# Altium schematic sheet Schematic_Board2.SchDoc
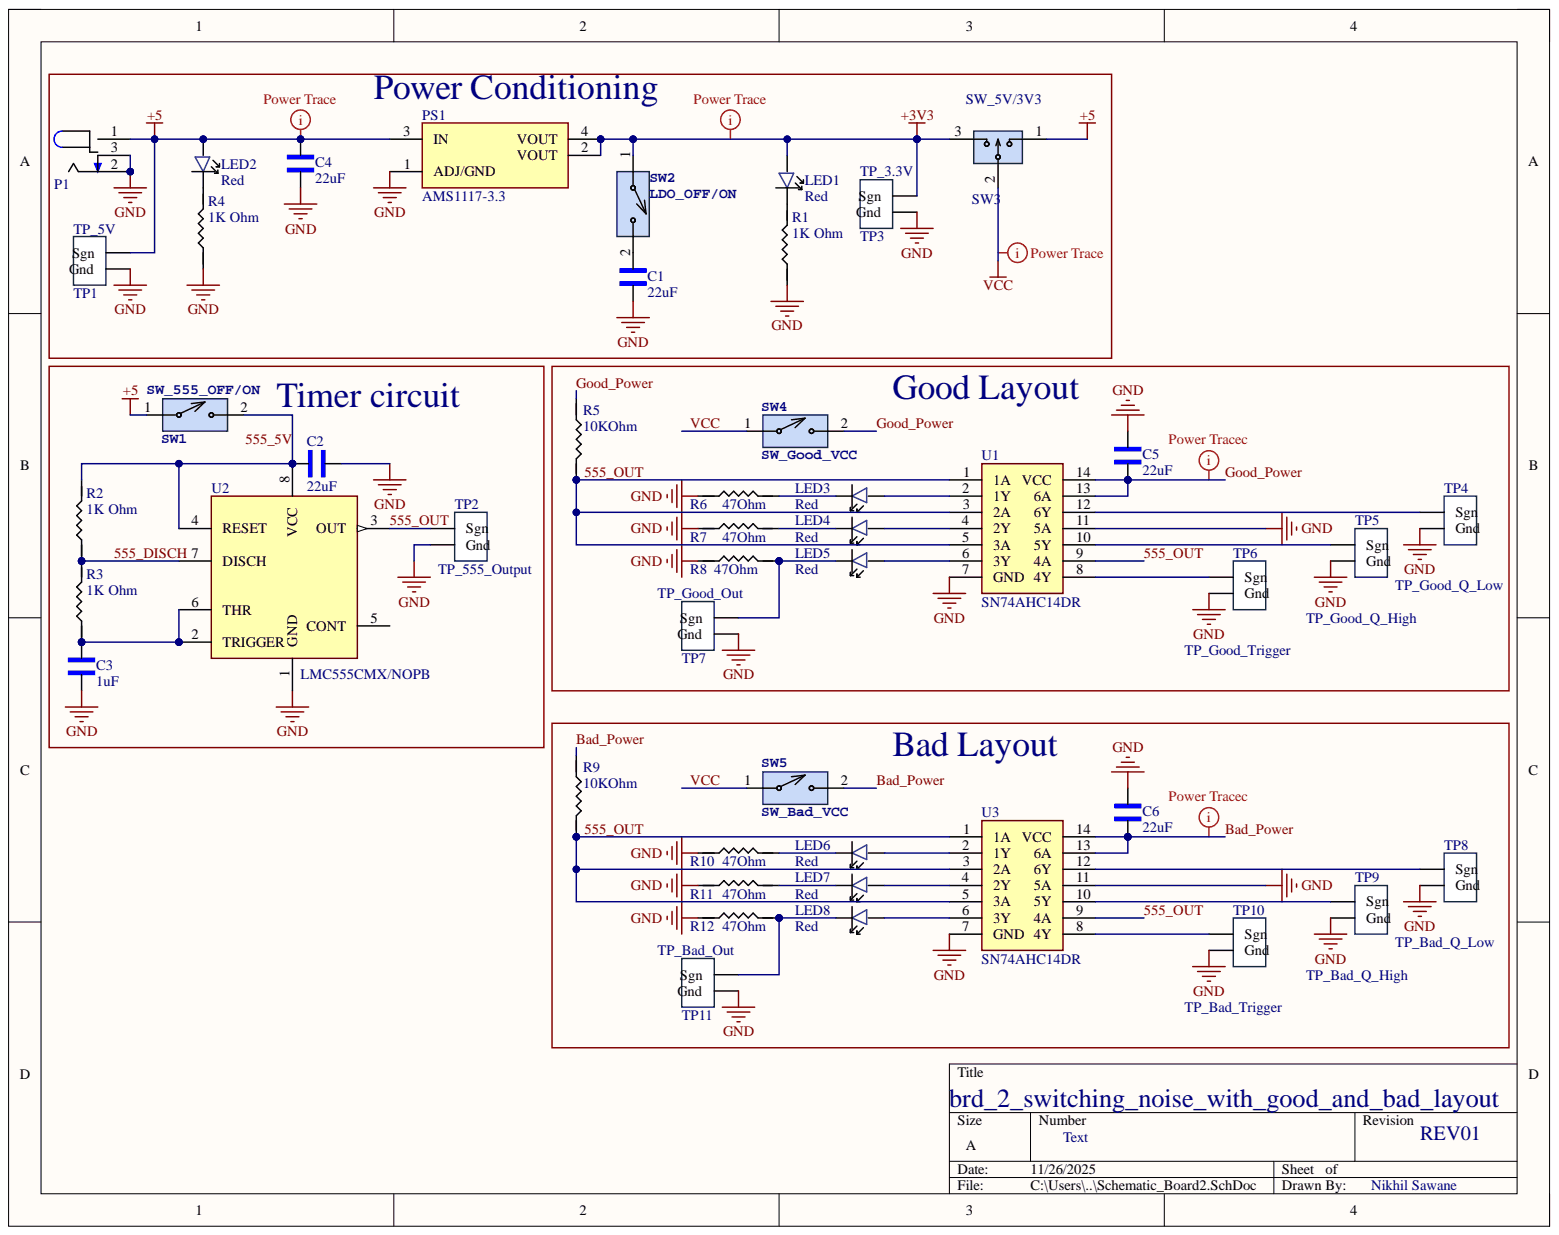
[diagram p0: Schematic_Board2.SchDoc]

## Parts
C1  22uF  [1206_Passive_Capacitor]
C2  22uF  [1206_Passive_Capacitor]
C3  1uF  [1206_Passive_Capacitor]
C4  22uF  [1206_Passive_Capacitor]
C5  22uF  [1206_Passive_Capacitor]
C6  22uF  [1206_Passive_Capacitor]
LED1  Red  [LED_1206]
LED2  Red  [LED_1206]
LED3  Red  [LED_1206]
LED4  Red  [LED_1206]
LED5  Red  [LED_1206]
LED6  Red  [LED_1206]
LED7  Red  [LED_1206]
LED8  Red  [LED_1206]
P1  Power Jack  [Power_Jack]  (value not drawn)
PS1  AMS1117-3.3  [LDO]
R1  value 1K Ohm  [1206_Passive_Resitor]  (hidden comment: 1k)
R2  value 1K Ohm  [1206_Passive_Resitor]  (hidden comment: 1k)
R3  value 1K Ohm  [1206_Passive_Resitor]  (hidden comment: 1k)
R4  value 1K Ohm  [1206_Passive_Resitor]  (hidden comment: 1k)
R5  value 10KOhm  [1206_Passive_Resitor]  (hidden comment: 10k)
R6  value 47Ohm  [1206_Passive_Resitor]  (hidden comment: 47 Ohms)
R7  value 47Ohm  [1206_Passive_Resitor]  (hidden comment: 47 Ohms)
R8  value 47Ohm  [1206_Passive_Resitor]  (hidden comment: 47 Ohms)
R9  value 10KOhm  [1206_Passive_Resitor]  (hidden comment: 10k)
R10  value 47Ohm  [1206_Passive_Resitor]  (hidden comment: 47 Ohms)
R11  value 47Ohm  [1206_Passive_Resitor]  (hidden comment: 47 Ohms)
R12  value 47Ohm  [1206_Passive_Resitor]  (hidden comment: 47 Ohms)
SW1  SW_555_OFF/ON  [2Pin-Header-Conn]
SW2  LDO_OFF/ON  [2Pin-Header-Conn]
SW3  SW_5V/3V3  [3_PIN_100mil]
SW4  SW_Good_VCC  [2Pin-Header-Conn]
SW5  SW_Bad_VCC  [2Pin-Header-Conn]
TP1  TP_5V  [TP10x_Probe]
TP2  TP_555_Output  [TP10x_Probe]
TP3  TP_3.3V  [TP10x_Probe]
TP4  TP_Good_Q_Low  [TP10x_Probe]
TP5  TP_Good_Q_High  [TP10x_Probe]
TP6  TP_Good_Trigger  [TP10x_Probe]
TP7  TP_Good_Out  [TP10x_Probe]
TP8  TP_Bad_Q_Low  [TP10x_Probe]
TP9  TP_Bad_Q_High  [TP10x_Probe]
TP10  TP_Bad_Trigger  [TP10x_Probe]
TP11  TP_Bad_Out  [TP10x_Probe]
U1  SN74AHC14DR  [HEX_74AC14]
U2  LMC555CMX/NOPB  [TS555IDTTR]
U3  SN74AHC14DR  [HEX_74AC14]

## Nets
+3V3: LED1.1 PS1.2(VOUT) PS1.4(VOUT) SW2.1 SW3.3 TP3.1
+5: C4.2 LED2.1 P1.1 PS1.3(IN) SW1.1 SW3.1 TP1.1
GND: C1.1 C2.1 C3.1 C4.1 C5.2 C6.2 P1.2 P1.3 PS1.1(ADJ/GND) R1.1 R4.1 R6.1 R7.1 R8.1 R10.1 R11.1 R12.1 TP1.2 TP2.2 TP3.2 TP4.2 TP5.2 TP6.2 TP7.2 TP8.2 TP9.2 TP10.2 TP11.2 U1.7(GND) U1.11(5A) U2.1(GND) U3.7(GND) U3.11(5A)
555_5V: C2.2 R2.2 SW1.2 U2.4(RESET) U2.8(VCC)
555_DISCH: R2.1 R3.2 U2.7(DISCH)
555_OUT: R5.2 R9.2 TP2.1 U1.1(1A) U1.3(2A) U1.5(3A) U1.9(4A) U2.3(OUT) U3.1(1A) U3.3(2A) U3.5(3A) U3.9(4A)
Bad_Power: C6.1 R9.1 SW5.2 U3.13(6A) U3.14(VCC)
Good_Power: C5.1 R5.1 SW4.2 U1.13(6A) U1.14(VCC)
VCC: SW3.2 SW4.1 SW5.1
NetC1_2: C1.2 SW2.2
NetC3_2: C3.2 R3.1 U2.2(TRIGGER) U2.6(THR)
NetLED1_2: LED1.2 R1.2
NetLED2_2: LED2.2 R4.2
NetLED3_1: LED3.1 U1.2(1Y)
NetLED3_2: LED3.2 R6.2
NetLED4_1: LED4.1 U1.4(2Y)
NetLED4_2: LED4.2 R7.2
NetLED5_1: LED5.1 U1.6(3Y)
NetLED5_2: LED5.2 R8.2 TP7.1
NetLED6_1: LED6.1 U3.2(1Y)
NetLED6_2: LED6.2 R10.2
NetLED7_1: LED7.1 U3.4(2Y)
NetLED7_2: LED7.2 R11.2
NetLED8_1: LED8.1 U3.6(3Y)
NetLED8_2: LED8.2 R12.2 TP11.1
NetTP4_1: TP4.1 U1.12(6Y)
NetTP5_1: TP5.1 U1.10(5Y)
NetTP6_1: TP6.1 U1.8(4Y)
NetTP8_1: TP8.1 U3.12(6Y)
NetTP9_1: TP9.1 U3.10(5Y)
NetTP10_1: TP10.1 U3.8(4Y)

Not connected: U2 5(CONT)

## Library data of the parts
C1 C2 C4-C6 = C_22uF_1206: 22uF ±10% 25V X5R 1206 Multilayer Ceramic Capacitors MLCC - SMD/SMT RoHS; MFR Part #: CL31A226KAHNNNE; Type: basic; Component Kind: Standard; Datasheet: https://datasheet.lcsc.com/lcsc/1811031514_Samsung-Electro-Mechanics-CL31A226KAHNNNE_C12891.pdf; LCSC Part#: C12891; Library Name: ECEN5730Lib_2023_05_DS.IntLib; Value: 22uF
C3 = C_1uF_1206: MULTILAYER CERAMIC CAPACITORS MLCC - SMD/SMT 1UF 50V 1206 ROHS; MFR Part #: CL31B105KBHNNNE; Type: Basic; Component Kind: Standard; Digikey Link: https://www.digikey.com/product-detail/en/C1206C105M4RACTU/399-8150-1-ND/3471873/?itemSeq=304982366; LCSC Part#: C1848; Library Name: ECEN5730Lib_2023_05_DS.IntLib; Value: 1uF
LED1-LED8 = LED_RED_1206: Red 621~631nm 1206 Light Emitting Diodes (LED) RoHS; MFR Part #: 15-21/R6C-FQ1R1B/2T; Type: Extended; Component Kind: Standard; Datasheet: https://datasheet.lcsc.com/szlcsc/1811151241_Everlight-Elec-15-21-R6C-FQ1R1B-2T_C93133.pdf; LCSC Part#: C93133; Library Name: ECEN5730Lib_2023_05_DS.IntLib; Value: Red
P1 = P_Power Jack: Power Barrel Connector Jack 2.10mm ID (0.083"), 5.50mm OD (0.217") Through Hole, Right Angle; MFR Part #: PJ-102A; Value: CONN PWR JACK 2X5.5MM SOLDER; Component Kind: Standard; Digikey Link: https://www.digikey.com/product-detail/en/PJ-102A/CP-102A-ND/275425/?itemSeq=304982367; LCSC Part#: C136744; Library Name: ECEN5730Lib_2023_05_DS.IntLib; Type: Extended
PS1 = PS_AMS_LDO_3v3: LOW DROPOUT REGULATORS(LDO) POSITIVE FIXED 1.3V @ 800MA 15V 3.3V 1A SOT-223 ROHS; Value: IC REG LINEAR 3.3V 800MA SOT223; Component Kind: Standard; LCSC Part#: C6186; Library Name: ECEN5730Lib_2023_05_DS.IntLib; MFR Part #: AMS1117-3.3; Type: Basic
R1-R4 = R_1K_1206: CHIP RESISTOR - SURFACE MOUNT 1KOHMS ±1% 1/4W 1206 ROHS; MFR Part #: RNCP1206FTD1K00CT; Component Kind: Standard; Digikey Link: https://www.digikey.com/product-detail/en/RNCP1206FTD1K00/RNCP1206FTD1K00CT-ND/2240675/?itemSeq=304982373; LCSC Part#: C4410; Library Name: ECEN5730Lib_2023_05_DS.IntLib; Type: Basic
R5 R9 = R_10KOhm_1206: 10 kOhms ±5% 0.25W, 1/4W Chip Resistor 1206 (3216 Metric) Automotive AEC-Q200 Thick Film; MFR Part #: RMCF1206FT10K0; Component Kind: Standard; Digikey Link: https://www.digikey.com/en/products/detail/stackpole-electronics-inc/RMCF1206FT10K0/1759669; LCSC Part#: C17902; Library Name: ECEN5730Lib_2023_05_DS.IntLib; Type: Basic
R6-R8 R10-R12 = R_47Ohm_1206: 47Ω ±5% 0.25W ±200ppm/℃ 1206 Chip Resistor - Surface Mount RoHS; MFR Part #: 1206W4J0470T5E; Component Kind: Standard; Datasheet: https://datasheet.lcsc.com/lcsc/1811101020_UNI-ROYAL-Uniroyal-Elec-1206W4J0470T5E_C25384.pdf; LCSC Part#: C25384; Library Name: ECEN5730Lib_2023_05_DS.IntLib; Type: Extended
SW1 SW2 SW4 SW5 = SW_2Pin_100mil_Switch: 2Pin Header; Component Kind: Standard; LCSC Part#: C124375; Library Name: ECEN5730Lib_2023_05_DS.IntLib; Type: Extended
SW3 = SW_3Pin_100mil_Switch: Connector Header Through Hole 3 position 0.100" (2.54mm); MFR Part #: PREC003SAAN-RC; Value: CONN HEADER VERT 3POS 2.54MM; Component Kind: Standard; Digikey Link: https://www.digikey.com/product-detail/en/PREC003SAAN-RC/S1012EC-03-ND/2774851/?itemSeq=304982371; LCSC Part#: C49257; Library Name: ECEN5730Lib_2023_05_DS.IntLib; Type: Extended
TP1-TP11 = TP_10x_Probe: Test Point 300 mil centers; Component Kind: Standard; Library Name: ECEN5730Lib_2023_05_DS.IntLib
U1 U3 = U_74AHC14: Inverter IC 6 Channel Schmitt Trigger 14-SOIC; Component Kind: Standard; Datasheet: https://datasheet.lcsc.com/szlcsc/ON-Semicon-ON-74AC14SCX_C5482.pdf; LCSC Part#: C6942; Library Name: ECEN5730Lib_2023_05_DS.IntLib; MFR Part #: SN74AHC14DR; Type: Extended
U2 = U_555_Fast: 555 Type, Timer/Oscillator _Single_ IC 3MHz 8-SO; Component Kind: Standard; DigiKey link: https://www.digikey.com/product-detail/en/texas-instruments/LMC555CMX-NOPB/LMC555CMX-NOPBCT-ND/1010550; LCSC Part#: C90760; Library Name: ECEN5730Lib_2023_05_DS.IntLib; MFR Part #: LMC555CMX/NOPB; Package: SOIC-8; Type: Extended
